# Altium schematic sheet ttl2rs485.SchDoc
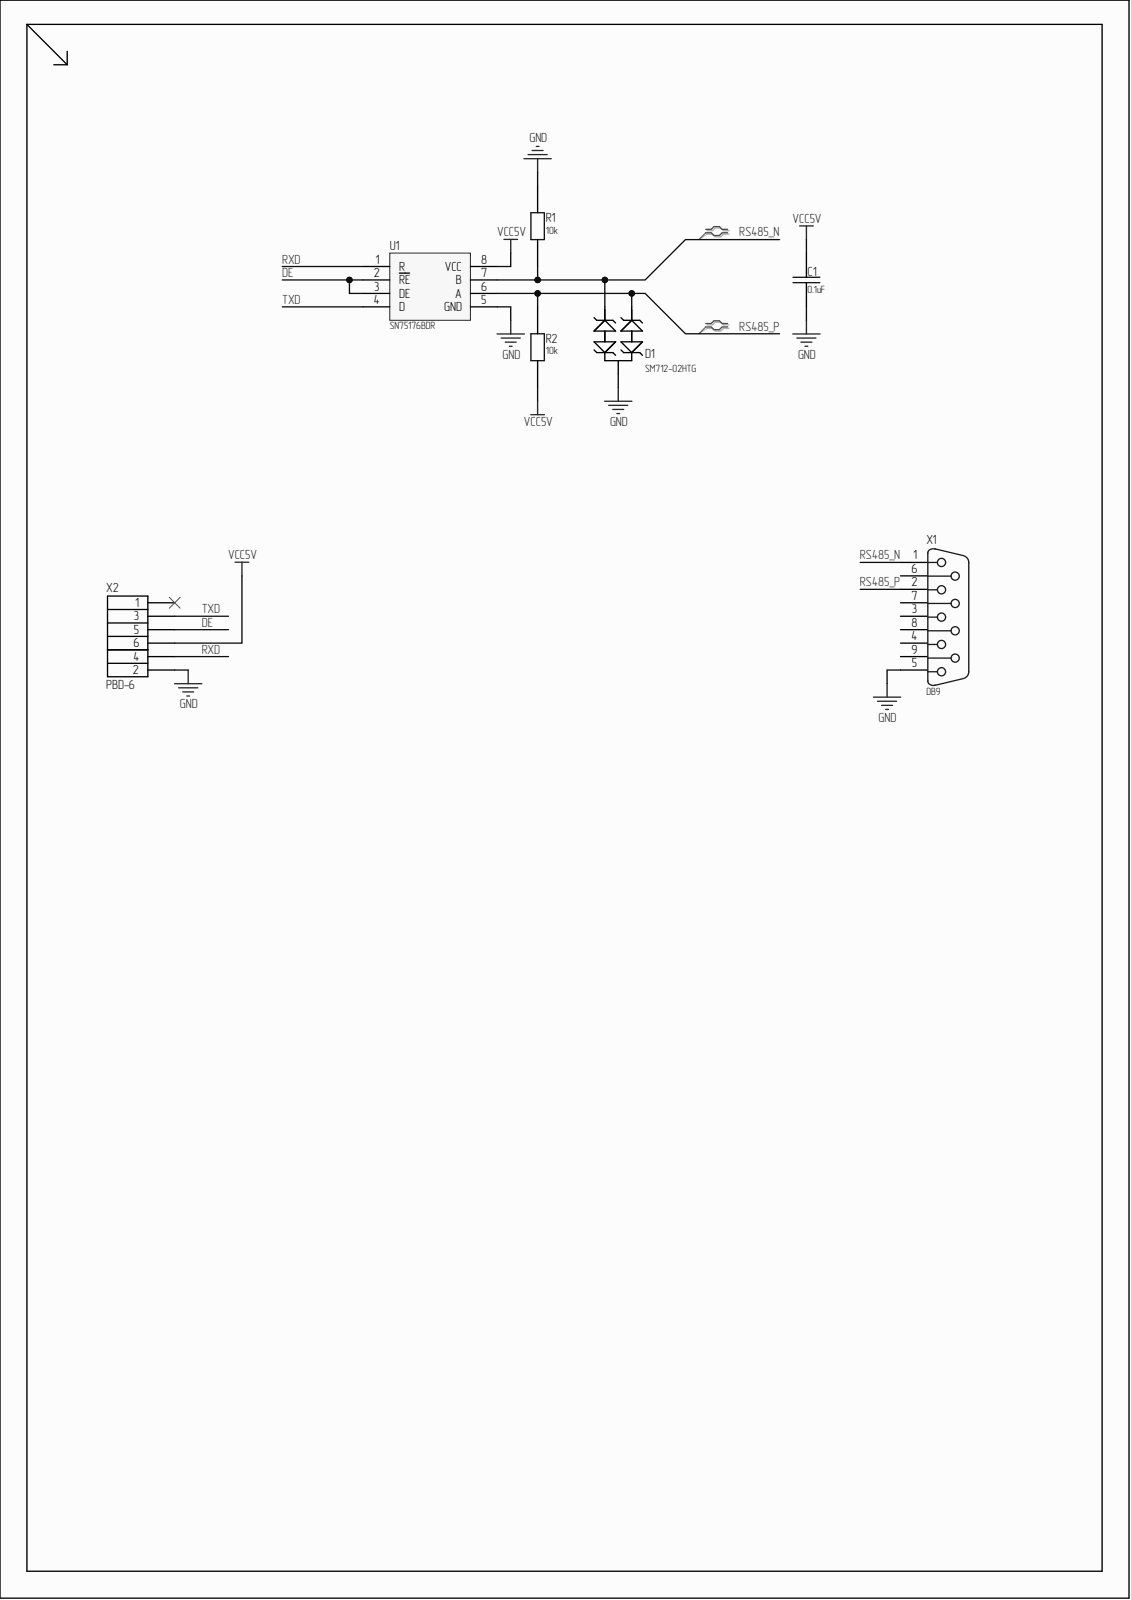
[diagram p0: ttl2rs485.SchDoc]

## Parts
C1  0.1uF  [C_0603]
D1  SM712-02HTG  [SOT23]
R1  10k  [R_0603]
R2  10k  [R_0603]
U1  SN75176BDR  [SO-8]
X1  DB9  [DB9_template]  (no BOM)
X2  PBD-6  [PBD-6-sideways]

## Nets
GND: C1.1 D1.3 R1.2 U1.5(GND) X1.5 X2.2
VCC5V: C1.2 R2.1 U1.8(VCC) X2.6
DE: U1.2(RE) U1.3(DE) X2.5
RS485_N: D1.1 R1.1 U1.7(B) X1.1
RS485_P: D1.2 R2.2 U1.6(A) X1.2
RXD: U1.1(R) X2.4
TXD: U1.4(D) X2.3

Not connected: X1 3 4 6 7 8 9; X2 1

## Library data of the parts
C1 = CAP_SMD: Capacitor; Part Number: CC0603KPX7R9BB104; Component Kind: Standard; Library Name: capacitors.schlib; Value: 0.1uF
D1 = ESD: Component Kind: Standard; Description: ESD; Library Name: diode.schlib; Part Number: SM712-02HTG; Value: SM712-02HTG
R1 R2 = RES_SMD: Resistor; Part Number: RC0603FR-0710KL; Component Kind: Standard; Library Name: resistors.schlib; Value: 10k
U1 = SN75176BDR: RS485 Half Duplex Convertor; Component Kind: Standard; Library Name: discret_ic.schlib; Part Number: SN75176BDR
X1 = DB9_template: Connector; Library Name: connectors_socets.schlib
X2 = PBD-6: Header, 6-Pin, Dual row; Component Kind: Standard; Part Number: PBD-6; Library Name: connectors_socets.schlib
